# Altium schematic sheet JAMMA.SchDoc
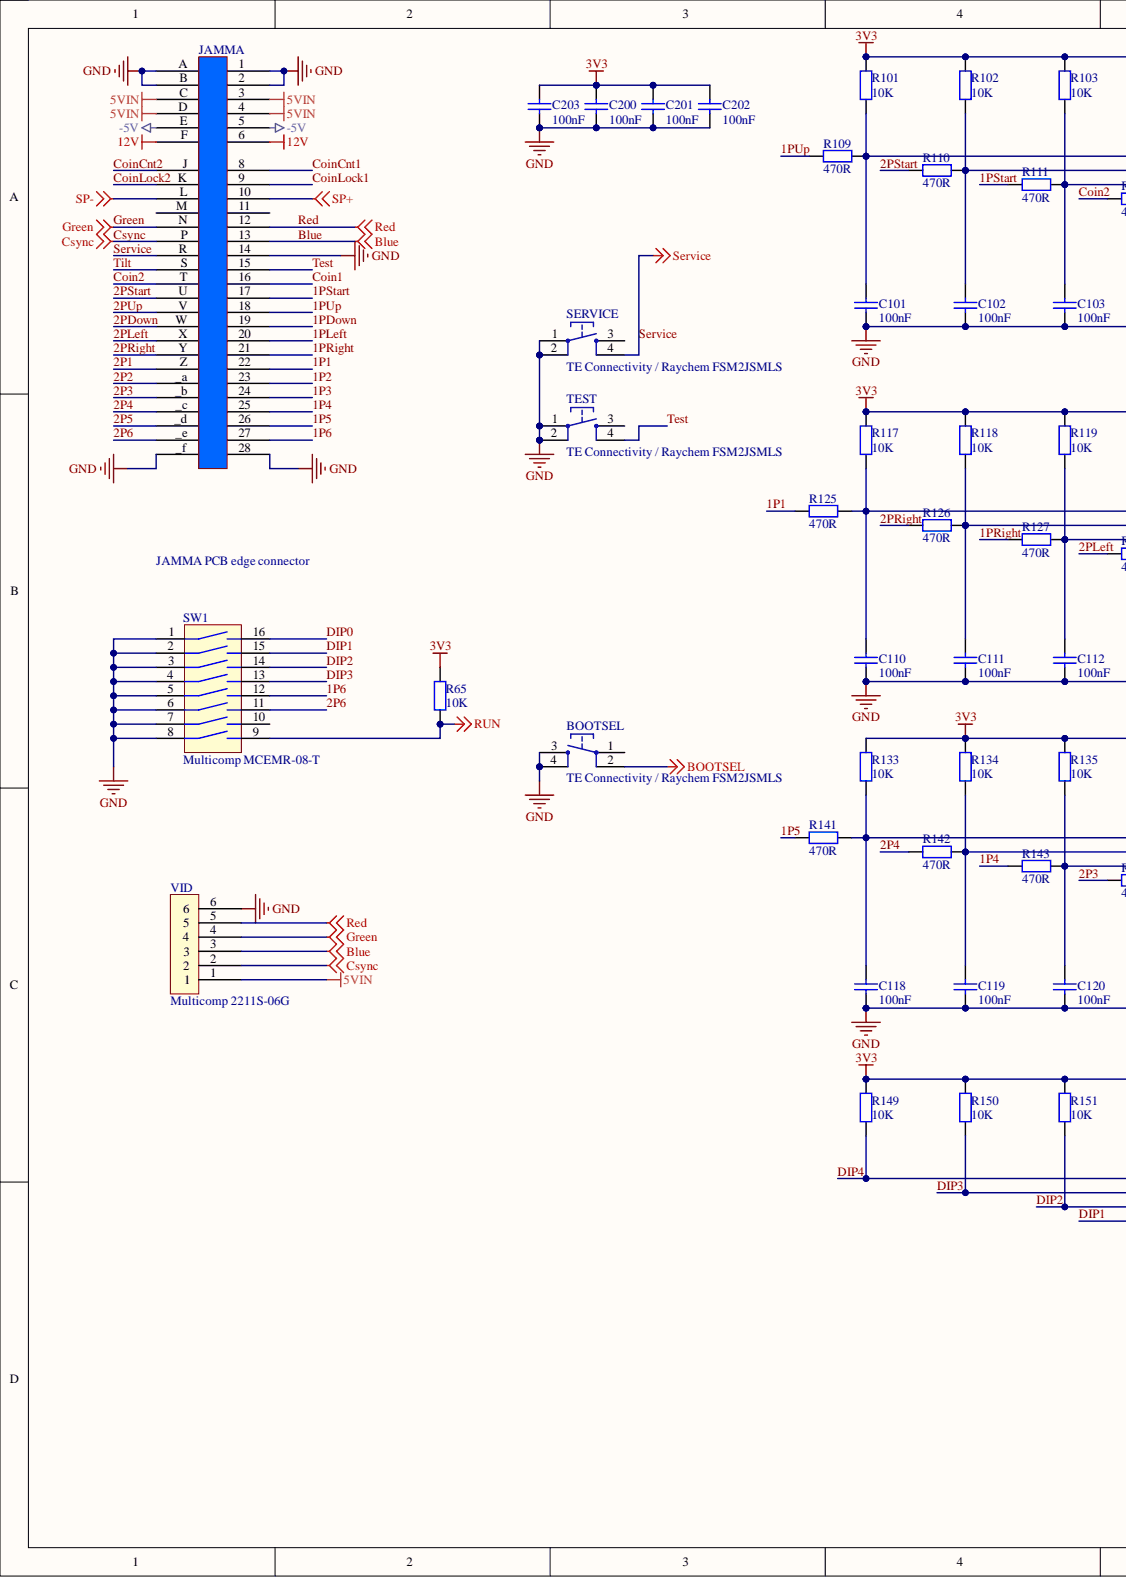
[diagram p0: JAMMA.SchDoc, left]
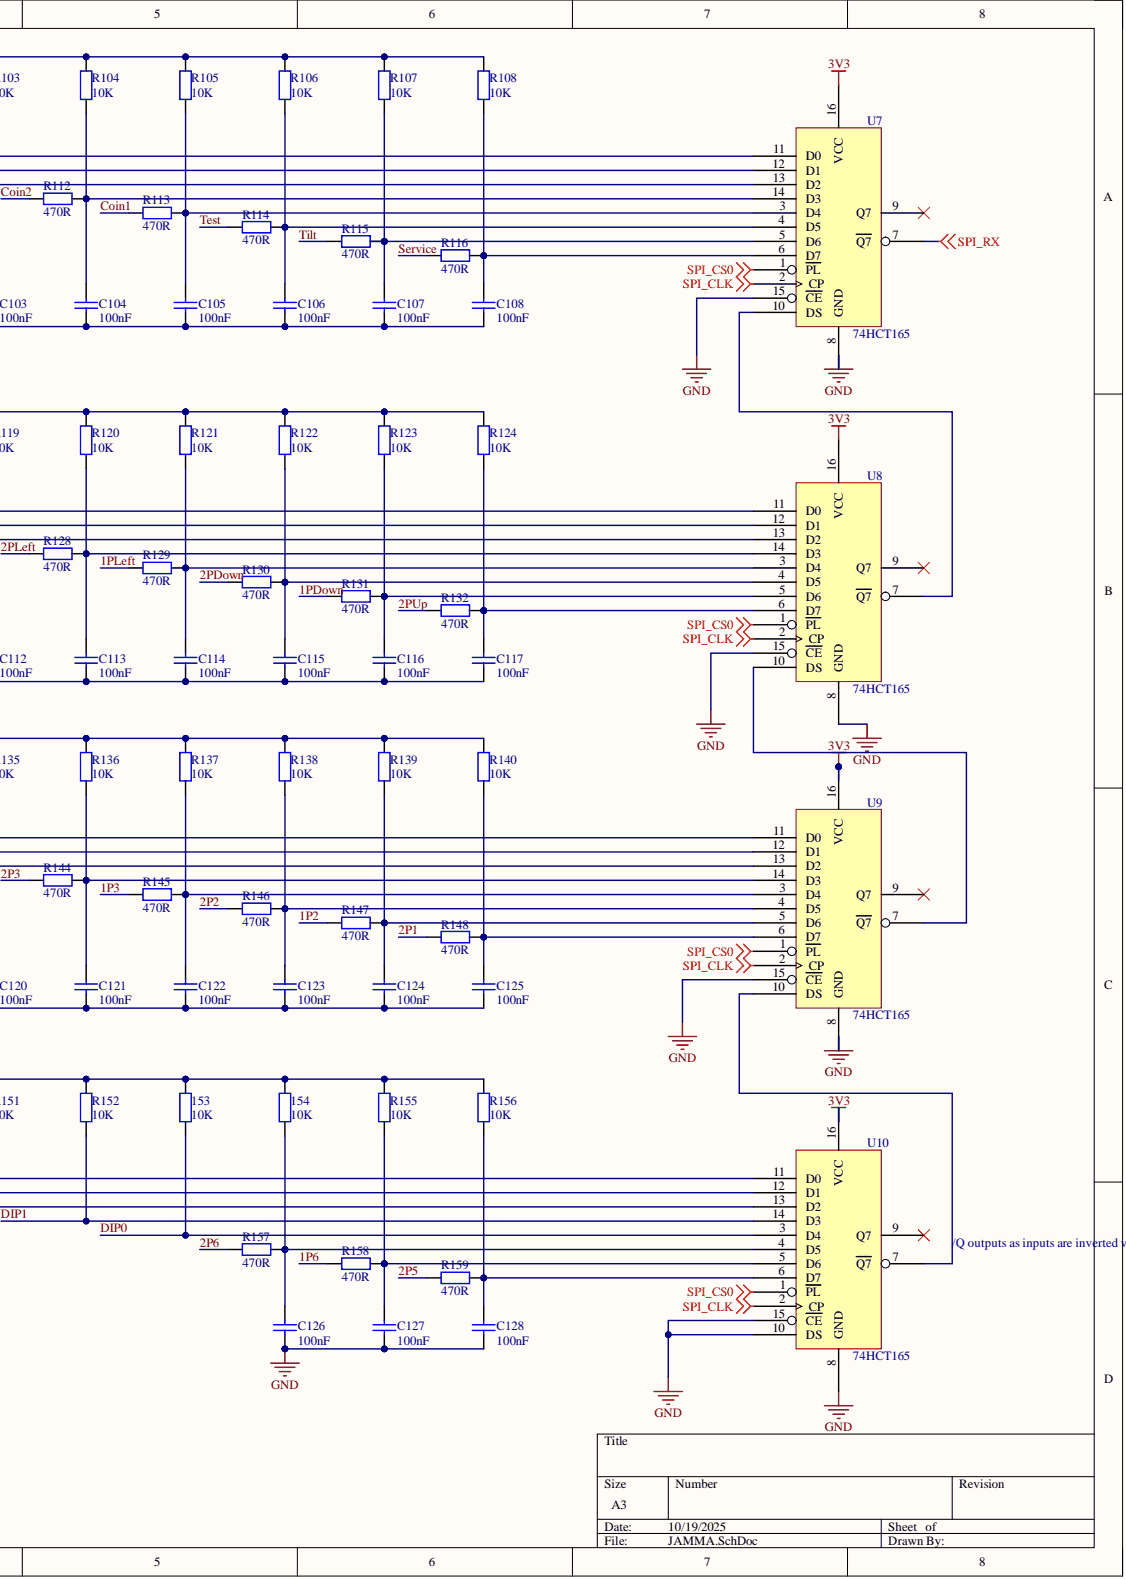
[diagram p1: JAMMA.SchDoc, right]

## Parts
153  10K  [PCB-7wrvqjq7seaxrusqyahf-1]
154  10K  [PCB-7wrvqjq7seaxrusqyahf-1]
BOOTSEL  TE Connectivity / Raychem FSM2JSMLS  [PCB-70a2cida5e6dyor38nlo-1]
C101  100nF  [PCB-god88euyegdvhk3bnqo6-1]
C102  100nF  [PCB-god88euyegdvhk3bnqo6-1]
C103  100nF  [PCB-god88euyegdvhk3bnqo6-1]
C104  100nF  [PCB-god88euyegdvhk3bnqo6-1]
C105  100nF  [PCB-god88euyegdvhk3bnqo6-1]
C106  100nF  [PCB-god88euyegdvhk3bnqo6-1]
C107  100nF  [PCB-god88euyegdvhk3bnqo6-1]
C108  100nF  [PCB-god88euyegdvhk3bnqo6-1]
C110  100nF  [PCB-god88euyegdvhk3bnqo6-1]
C111  100nF  [PCB-god88euyegdvhk3bnqo6-1]
C112  100nF  [PCB-god88euyegdvhk3bnqo6-1]
C113  100nF  [PCB-god88euyegdvhk3bnqo6-1]
C114  100nF  [PCB-god88euyegdvhk3bnqo6-1]
C115  100nF  [PCB-god88euyegdvhk3bnqo6-1]
C116  100nF  [PCB-god88euyegdvhk3bnqo6-1]
C117  100nF  [PCB-god88euyegdvhk3bnqo6-1]
C118  100nF  [PCB-god88euyegdvhk3bnqo6-1]
C119  100nF  [PCB-god88euyegdvhk3bnqo6-1]
C120  100nF  [PCB-god88euyegdvhk3bnqo6-1]
C121  100nF  [PCB-god88euyegdvhk3bnqo6-1]
C122  100nF  [PCB-god88euyegdvhk3bnqo6-1]
C123  100nF  [PCB-god88euyegdvhk3bnqo6-1]
C124  100nF  [PCB-god88euyegdvhk3bnqo6-1]
C125  100nF  [PCB-god88euyegdvhk3bnqo6-1]
C126  100nF  [PCB-god88euyegdvhk3bnqo6-1]
C127  100nF  [PCB-god88euyegdvhk3bnqo6-1]
C128  100nF  [PCB-god88euyegdvhk3bnqo6-1]
C200  100nF  [PCB-god88euyegdvhk3bnqo6-1]
C201  100nF  [PCB-god88euyegdvhk3bnqo6-1]
C202  100nF  [PCB-god88euyegdvhk3bnqo6-1]
C203  100nF  [PCB-god88euyegdvhk3bnqo6-1]
JAMMA  JAMMA PCB edge connector  [PCB-wm9rwrnrupqdi3mn2uoh-1]
R65  10K  [PCB-7wrvqjq7seaxrusqyahf-1]
R101  10K  [PCB-7wrvqjq7seaxrusqyahf-1]
R102  10K  [PCB-7wrvqjq7seaxrusqyahf-1]
R103  10K  [PCB-7wrvqjq7seaxrusqyahf-1]
R104  10K  [PCB-7wrvqjq7seaxrusqyahf-1]
R105  10K  [PCB-7wrvqjq7seaxrusqyahf-1]
R106  10K  [PCB-7wrvqjq7seaxrusqyahf-1]
R107  10K  [PCB-7wrvqjq7seaxrusqyahf-1]
R108  10K  [PCB-7wrvqjq7seaxrusqyahf-1]
R109  470R  [PCB-7wrvqjq7seaxrusqyahf-1]
R110  470R  [PCB-7wrvqjq7seaxrusqyahf-1]
R111  470R  [PCB-7wrvqjq7seaxrusqyahf-1]
R112  470R  [PCB-7wrvqjq7seaxrusqyahf-1]
R113  470R  [PCB-7wrvqjq7seaxrusqyahf-1]
R114  470R  [PCB-7wrvqjq7seaxrusqyahf-1]
R115  470R  [PCB-7wrvqjq7seaxrusqyahf-1]
R116  470R  [PCB-7wrvqjq7seaxrusqyahf-1]
R117  10K  [PCB-7wrvqjq7seaxrusqyahf-1]
R118  10K  [PCB-7wrvqjq7seaxrusqyahf-1]
R119  10K  [PCB-7wrvqjq7seaxrusqyahf-1]
R120  10K  [PCB-7wrvqjq7seaxrusqyahf-1]
R121  10K  [PCB-7wrvqjq7seaxrusqyahf-1]
R122  10K  [PCB-7wrvqjq7seaxrusqyahf-1]
R123  10K  [PCB-7wrvqjq7seaxrusqyahf-1]
R124  10K  [PCB-7wrvqjq7seaxrusqyahf-1]
R125  470R  [PCB-7wrvqjq7seaxrusqyahf-1]
R126  470R  [PCB-7wrvqjq7seaxrusqyahf-1]
R127  470R  [PCB-7wrvqjq7seaxrusqyahf-1]
R128  470R  [PCB-7wrvqjq7seaxrusqyahf-1]
R129  470R  [PCB-7wrvqjq7seaxrusqyahf-1]
R130  470R  [PCB-7wrvqjq7seaxrusqyahf-1]
R131  470R  [PCB-7wrvqjq7seaxrusqyahf-1]
R132  470R  [PCB-7wrvqjq7seaxrusqyahf-1]
R133  10K  [PCB-7wrvqjq7seaxrusqyahf-1]
R134  10K  [PCB-7wrvqjq7seaxrusqyahf-1]
R135  10K  [PCB-7wrvqjq7seaxrusqyahf-1]
R136  10K  [PCB-7wrvqjq7seaxrusqyahf-1]
R137  10K  [PCB-7wrvqjq7seaxrusqyahf-1]
R138  10K  [PCB-7wrvqjq7seaxrusqyahf-1]
R139  10K  [PCB-7wrvqjq7seaxrusqyahf-1]
R140  10K  [PCB-7wrvqjq7seaxrusqyahf-1]
R141  470R  [PCB-7wrvqjq7seaxrusqyahf-1]
R142  470R  [PCB-7wrvqjq7seaxrusqyahf-1]
R143  470R  [PCB-7wrvqjq7seaxrusqyahf-1]
R144  470R  [PCB-7wrvqjq7seaxrusqyahf-1]
R145  470R  [PCB-7wrvqjq7seaxrusqyahf-1]
R146  470R  [PCB-7wrvqjq7seaxrusqyahf-1]
R147  470R  [PCB-7wrvqjq7seaxrusqyahf-1]
R148  470R  [PCB-7wrvqjq7seaxrusqyahf-1]
R149  10K  [PCB-7wrvqjq7seaxrusqyahf-1]
R150  10K  [PCB-7wrvqjq7seaxrusqyahf-1]
R151  10K  [PCB-7wrvqjq7seaxrusqyahf-1]
R152  10K  [PCB-7wrvqjq7seaxrusqyahf-1]
R155  10K  [PCB-7wrvqjq7seaxrusqyahf-1]
R156  10K  [PCB-7wrvqjq7seaxrusqyahf-1]
R157  470R  [PCB-7wrvqjq7seaxrusqyahf-1]
R158  470R  [PCB-7wrvqjq7seaxrusqyahf-1]
R159  470R  [PCB-7wrvqjq7seaxrusqyahf-1]
SERVICE  TE Connectivity / Raychem FSM2JSMLS  [PCB-70a2cida5e6dyor38nlo-1]
SW1  Multicomp MCEMR-08-T  Manufacturer Part Number: MCEMR-08-T  [PCB-emf22j391u7ddkiivr5u-1]
TEST  TE Connectivity / Raychem FSM2JSMLS  [PCB-70a2cida5e6dyor38nlo-1]
U7  NXP Semiconductors 74HCT165D  [PCB-qqngf8zj2l6qaavf2la0-1]  (value not drawn)
U8  NXP Semiconductors 74HCT165D  [PCB-qqngf8zj2l6qaavf2la0-1]  (value not drawn)
U9  NXP Semiconductors 74HCT165D  [PCB-qqngf8zj2l6qaavf2la0-1]  (value not drawn)
U10  NXP Semiconductors 74HCT165D  [PCB-qqngf8zj2l6qaavf2la0-1]  (value not drawn)
VID  Multicomp 2211S-06G  Manufacturer Part Number: 2211S-06G  [PCB-ehkh6f0z1u7zp84fuabo-1]

## Nets
3V3: 153.1 154.1 C200.2 C201.2 C202.2 C203.2 R65.1 R101.1 R102.1 R103.1 R104.1 R105.1 R106.1 R107.1 R108.1 R117.1 R118.1 R119.1 R120.1 R121.1 R122.1 R123.1 R124.1 R133.1 R134.1 R135.1 R136.1 R137.1 R138.1 R139.1 R140.1 R149.1 R150.1 R151.1 R152.1 R155.1 R156.1 U7.16(VCC) U8.16(VCC) U9.16(VCC) U10.16(VCC)
5VIN: JAMMA.3 JAMMA.4 JAMMA.C JAMMA.D VID.1
12V: JAMMA.6 JAMMA.F
-5V: JAMMA.5 JAMMA.E
BOOTSEL: BOOTSEL.2
GND: BOOTSEL.3 BOOTSEL.4 C101.1 C102.1 C103.1 C104.1 C105.1 C106.1 C107.1 C108.1 C110.1 C111.1 C112.1 C113.1 C114.1 C115.1 C116.1 C117.1 C118.1 C119.1 C120.1 C121.1 C122.1 C123.1 C124.1 C125.1 C126.1 C127.1 C128.1 C200.1 C201.1 C202.1 C203.1 JAMMA.1 JAMMA.2 JAMMA.14 JAMMA.28 JAMMA._f JAMMA.A JAMMA.B SERVICE.1 SERVICE.2 SW1.1 SW1.2 SW1.3 SW1.4 SW1.5 SW1.6 SW1.7 SW1.8 TEST.1 TEST.2 U7.8(GND) U7.15(CE) U8.8(GND) U8.15(CE) U9.8(GND) U9.15(CE) U10.8(GND) U10.10(DS) U10.15(CE) VID.6
RUN: R65.2 SW1.9
SP+: JAMMA.10
SP-: JAMMA.L
SPI_CLK: U7.2(CP) U8.2(CP) U9.2(CP) U10.2(CP)
SPI_CS0: U7.1(PL) U8.1(PL) U9.1(PL) U10.1(PL)
SPI_RX: U7.7(Q7)
1P1: JAMMA.22 R125.2
1P2: JAMMA.23 R147.2
1P3: JAMMA.24 R145.2
1P4: JAMMA.25 R143.2
1P5: JAMMA.26 R141.2
1P6: JAMMA.27 R158.2 SW1.12
1PDown: JAMMA.19 R131.2
1PLeft: JAMMA.20 R129.2
1PRight: JAMMA.21 R127.2
1PStart: JAMMA.17 R111.2
1PUp: JAMMA.18 R109.2
2P1: JAMMA.Z R148.2
2P2: JAMMA._a R146.2
2P3: JAMMA._b R144.2
2P4: JAMMA._c R142.2
2P5: JAMMA._d R159.2
2P6: JAMMA._e R157.2 SW1.11
2PDown: JAMMA.W R130.2
2PLeft: JAMMA.X R128.2
2PRight: JAMMA.Y R126.2
2PStart: JAMMA.U R110.2
2PUp: JAMMA.V R132.2
Blue: JAMMA.13 VID.3
Coin1: JAMMA.16 R113.2
Coin2: JAMMA.T R112.2
CoinCnt1: JAMMA.8
CoinCnt2: JAMMA.J
CoinLock1: JAMMA.9
CoinLock2: JAMMA.K
Csync: JAMMA.P VID.2
DIP0: 153.2 SW1.16 U10.3(D4)
DIP1: R152.2 SW1.15 U10.14(D3)
DIP2: R151.2 SW1.14 U10.13(D2)
DIP3: R150.2 SW1.13 U10.12(D1)
DIP4: R149.2 U10.11(D0)
Green: JAMMA.N VID.4
Red: JAMMA.12 VID.5
Service: JAMMA.R R116.2 SERVICE.4
Test: JAMMA.15 R114.2 TEST.4
Tilt: JAMMA.S R115.2
Net154_2: 154.2 C126.2 R157.1 U10.4(D5)
NetC101_2: C101.2 R101.2 R109.1 U7.11(D0)
NetC102_2: C102.2 R102.2 R110.1 U7.12(D1)
NetC103_2: C103.2 R103.2 R111.1 U7.13(D2)
NetC104_2: C104.2 R104.2 R112.1 U7.14(D3)
NetC105_2: C105.2 R105.2 R113.1 U7.3(D4)
NetC106_2: C106.2 R106.2 R114.1 U7.4(D5)
NetC107_2: C107.2 R107.2 R115.1 U7.5(D6)
NetC108_2: C108.2 R108.2 R116.1 U7.6(D7)
NetC110_2: C110.2 R117.2 R125.1 U8.11(D0)
NetC111_2: C111.2 R118.2 R126.1 U8.12(D1)
NetC112_2: C112.2 R119.2 R127.1 U8.13(D2)
NetC113_2: C113.2 R120.2 R128.1 U8.14(D3)
NetC114_2: C114.2 R121.2 R129.1 U8.3(D4)
NetC115_2: C115.2 R122.2 R130.1 U8.4(D5)
NetC116_2: C116.2 R123.2 R131.1 U8.5(D6)
NetC117_2: C117.2 R124.2 R132.1 U8.6(D7)
NetC118_2: C118.2 R133.2 R141.1 U9.11(D0)
NetC119_2: C119.2 R134.2 R142.1 U9.12(D1)
NetC120_2: C120.2 R135.2 R143.1 U9.13(D2)
NetC121_2: C121.2 R136.2 R144.1 U9.14(D3)
NetC122_2: C122.2 R137.2 R145.1 U9.3(D4)
NetC123_2: C123.2 R138.2 R146.1 U9.4(D5)
NetC124_2: C124.2 R139.2 R147.1 U9.5(D6)
NetC125_2: C125.2 R140.2 R148.1 U9.6(D7)
NetC127_2: C127.2 R155.2 R158.1 U10.5(D6)
NetC128_2: C128.2 R156.2 R159.1 U10.6(D7)
NetU7_10: U7.10(DS) U8.7(Q7)
NetU8_10: U8.10(DS) U9.7(Q7)
NetU9_10: U9.10(DS) U10.7(Q7)

Not connected: BOOTSEL 1; JAMMA 11 M; SERVICE 3; SW1 10; TEST 3; U7 9(Q7); U8 9(Q7); U9 9(Q7); U10 9(Q7)

## Library data of the parts
153 154 R65 R101-R152 R155-R159 = CMP-3bd8f394a633e41f-2: Res Thin Film 2.0 x 1.2 mm 2K Ohm 0.1% 0.1W(1/10W) 10ppm/ C Molded SMD Paper T/R; Manufacturer Part Number: RN73C2A2K0BTD; Case/Package (SI): 2012; ELV Compliant: Compliant; Power Rating: 100 mW; Resistance: 2.00 kΩ; Voltage Rating (DC): 100 V; Case/Package: 0805; Component Kind: Standard; Library Name: My Personal Space; Lifecycle Status: Active; Mounting Style: Surface Mount; Number of Pins: 2; Octopart URL: http://octopart.com/rn73c2a2k0btdf-te+connectivity-21411981; OctopartID: 3bd8f394a633e41f; Packaging: Tape & Reel (TR); REACH SVHC Compliance: No SVHC; Resistance Tolerance: ±0.1%; RoHS: Compliant
BOOTSEL SERVICE TEST = CMP-54d699b8c6238b11-3: Switch Tactile OFF (ON) SPST Round Button Gull Wing 0.05A 24VDC 1000000Cycles 0.98N SMD Loose; Manufacturer Part Number: 1977223-1; Lead-Free Status: Lead Free; Component Kind: Standard; Library Name: My Personal Space; Lifecycle Status: Not Listed by Manufacturer; Manufacturer URL: http://www.te.com/usa-en/product-1977223-1.html; Mounting Style: Surface Mount; Octopart URL: http://octopart.com/fsm2jsmls-te+connectivity+%2F+raychem-73709459; OctopartID: 54d699b8c6238b11; Packaging: Bulk; REACH SVHC Compliance: No SVHC; RoHS: Compliant
C101-C108 C110-C128 C200-C203 = CMP-ee2a246dec7f31ed-7: Capacitor; Ceramic; Cap 0.1 uF; Tol 10%; Vol-Rtg 25 VDC; SMT; 0805; X7R; Tape & Reel; Component Kind: Standard; Library Name: My Personal Space
JAMMA = CMP-ua3col05nmarlnbwbj30-2: JAMMA PCB edge connector, 56-way; Component Kind: Standard; CustomComponentID: ua3col05nmarlnbwbj30; Library Name: FavoritesLibrary
SW1 = CMP-c6390f41653119fe-1: Switch, DIP, 8 Position, SPST, Recessed; Actuator Type: Standard; Contact Resistance: 100 mΩ; Current Rating: 25.0 mA; Color: Black; Component Kind: Standard; Contact Plating: Gold; Contacts Type: SPST; Flammability Rating: UL 94 V-0; Insulation Resistance: 100 MΩ; Library Name: My Personal Space; Mounting Style: Surface Mount; Octopart URL: https://octopart.com/mcemr-08-t-multicomp-7505518; OctopartID: c6390f41653119fe; Operating Temperature: -20.0 °C (min); REACH SVHC Compliance: No SVHC; RoHS: Compliant; Size-Height: 2.80 mm
U7-U10 = CMP-deaa17a75fc7016d-2: Shift Register Single 8-Bit Serial/Parallel to Serial 16-Pin SO; Frequency: 48.0 MHz; Lead-Free Status: Lead Free; Number of Bits: 8; Number of Gates: 1; Supply Voltage (DC): 5.00 V, 6.00 V (max); Case/Package: SOIC; Component Kind: Standard; Library Name: My Personal Space; Lifecycle Status: Not Listed by Manufacturer; Manufacturer Part Number: 74HCT165D; Mounting Style: Surface Mount; Number of Circuits: 8; Number of Pins: 16; Octopart URL: http://octopart.com/74hct165d-nxp+semiconductors-500747; OctopartID: deaa17a75fc7016d; Packaging: Tube; REACH SVHC Compliance: No SVHC; RoHS: Compliant
VID = CMP-32c6fbb6db0fed01-1: BOARD TO BOARD CONNECTOR; Connector Type: Board-to-Board; Pitch Spacing: 2.54mm; No. of Rows: 1; No. of Contacts: 6; ...; Contact Resistance: 20.0 mΩ; Current Rating: 3.00 A; Gender: Male; Insulation Resistance: 1.00 GΩ; Number of Contacts: 6; Voltage Rating (AC): 250 V; Color: Black; Component Kind: Standard; Contact Material: Copper Alloy; Contact Plating: Gold; Flammability Rating: UL 94 V-0; Library Name: My Personal Space; Mounting Style: Through Hole, Solder; Octopart URL: http://octopart.com/2211s-06g-multicomp-8047791; OctopartID: 32c6fbb6db0fed01; REACH SVHC Compliance: No SVHC; RoHS: Compliant
